# Altium schematic sheet Main.SchDoc
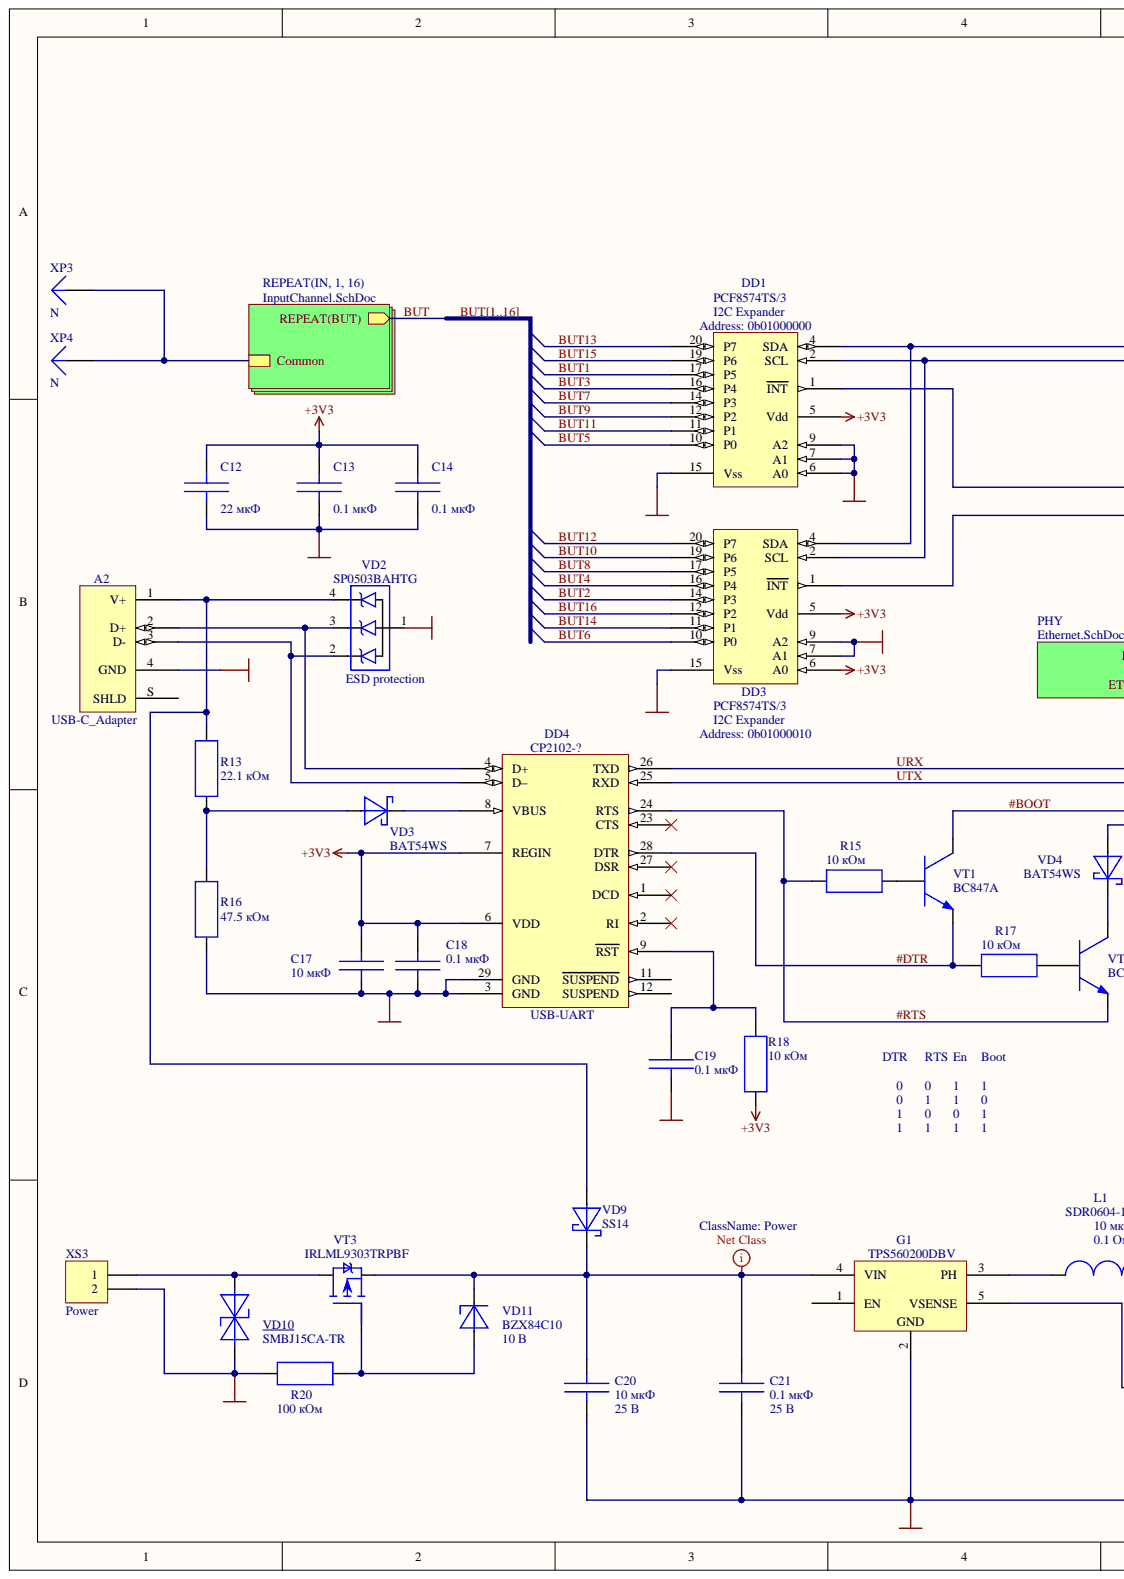
[diagram p0: Main.SchDoc, left]
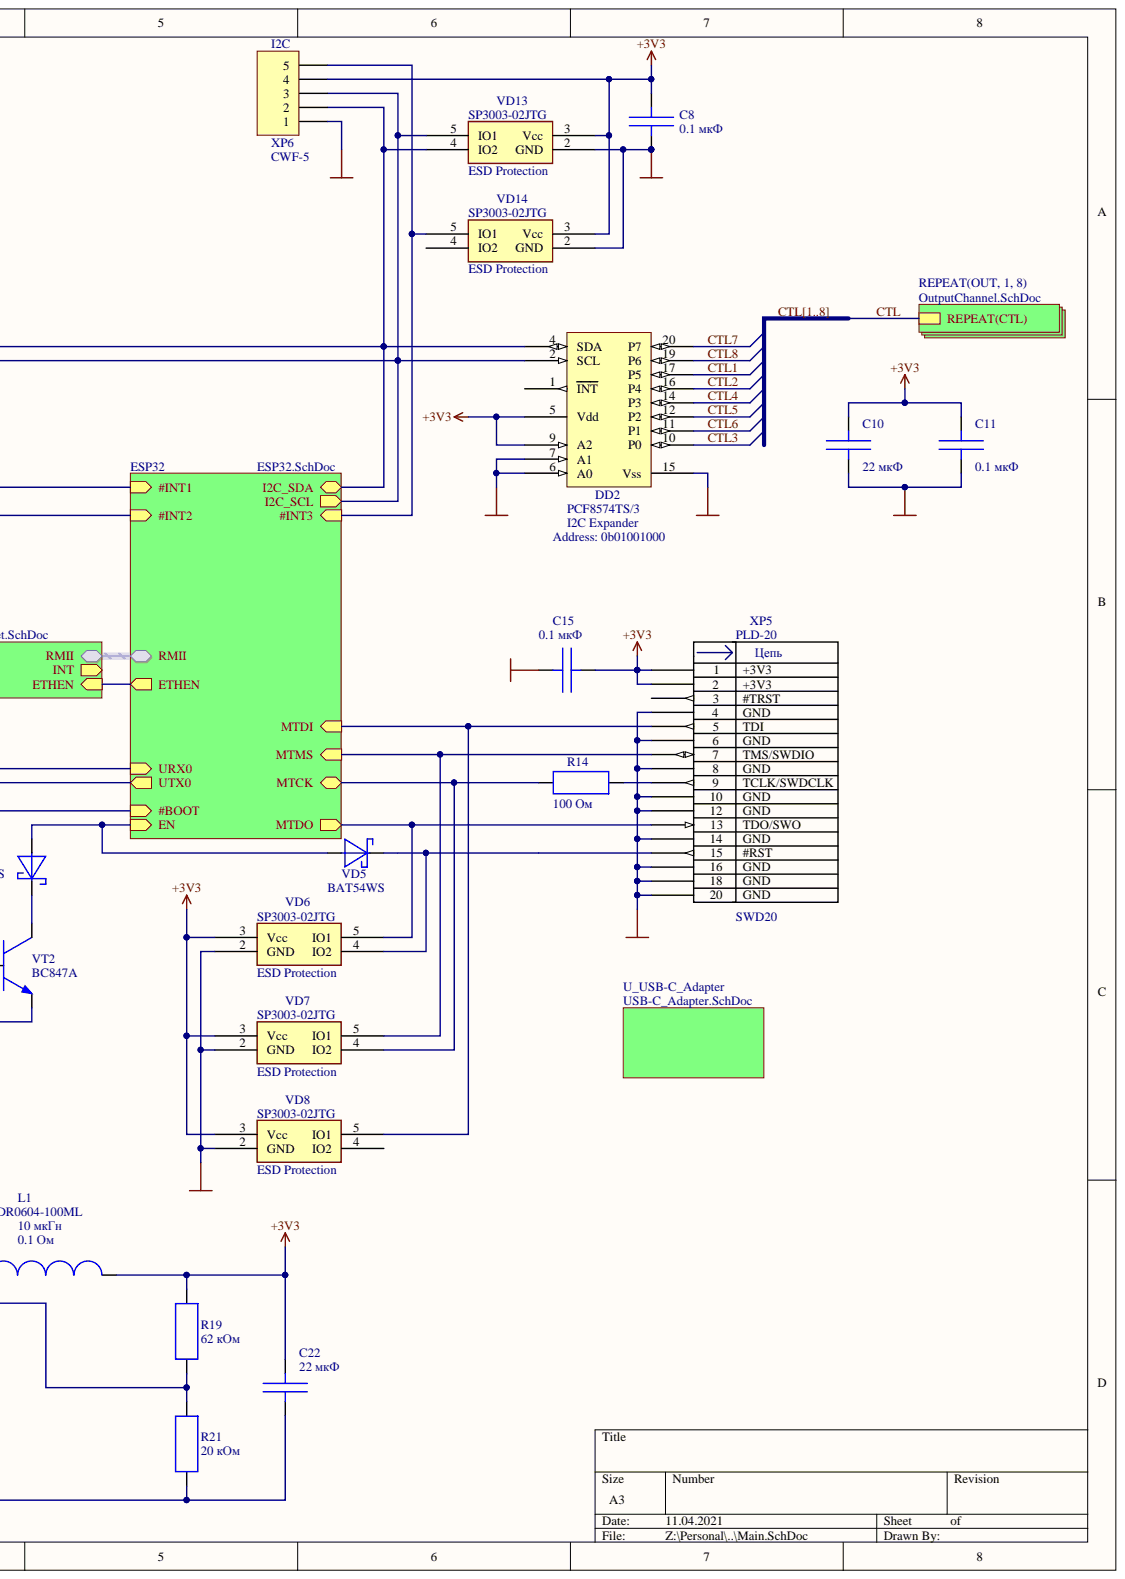
[diagram p1: Main.SchDoc, right]

## Parts
A2  USB-C_Adapter  [Usb-C_Adapter]  (no BOM)
C8  value 0.1 мкФ  [CAP_0603-1608]  (hidden comment: Cap)
C10  value 22 мкФ  [CAP_0805-2012]  (hidden comment: Cap)
C11  value 0.1 мкФ  [CAP_0603-1608]  (hidden comment: Cap)
C12  value 22 мкФ  [CAP_0805-2012]  (hidden comment: Cap)
C13  value 0.1 мкФ  [CAP_0603-1608]  (hidden comment: Cap)
C14  value 0.1 мкФ  [CAP_0603-1608]  (hidden comment: Cap)
C15  value 0.1 мкФ  [CAP_0603-1608]  (hidden comment: Cap)
C17  value 10 мкФ  [CAP_0603-1608]  (hidden comment: Cap)
C18  value 0.1 мкФ  [CAP_0603-1608]  (hidden comment: Cap)
C19  value 0.1 мкФ  [CAP_0603-1608]  (hidden comment: Cap)
C20  value 10 мкФ  Voltage: 25 В  [CAP_1206-3216]  (hidden comment: Cap)
C21  value 0.1 мкФ  Voltage: 25 В  [CAP_0805-2012]  (hidden comment: Cap)
C22  value 22 мкФ  [CAP_1206-3216]  (hidden comment: Cap)
DD1  I2C Expander  Address: 0b01000000  [TSSOP-0.65-20]
DD2  I2C Expander  [TSSOP-0.65-20]
DD3  I2C Expander  [TSSOP-0.65-20]
DD4  USB-UART  Type: CP2102-?  [QFN-0.5-28]
G1  Type: TPS560200DBV  [SOT-23-5]  (hidden comment: DC/DC converter)
L1  value 10 мкГн  Resistance: 0.1 Ом  [SDR0604]  (hidden comment: Inductor)
R13  value 22.1 кОм  [RES_0603-1608]  (hidden comment: Res)
R14  value 100 Ом  [RES_0603-1608]  (hidden comment: Res)
R15  value 10 кОм  [RES_0603-1608]  (hidden comment: Res)
R16  value 47.5 кОм  [RES_0603-1608]  (hidden comment: Res)
R17  value 10 кОм  [RES_0603-1608]  (hidden comment: Res)
R18  value 10 кОм  [RES_0603-1608]  (hidden comment: Res)
R19  value 62 кОм  [RES_0603-1608]  (hidden comment: Res)
R20  value 100 кОм  [RES_0603-1608]  (hidden comment: Res)
R21  value 20 кОм  [RES_0603-1608]  (hidden comment: Res)
VD2  ESD protection  [SOT143_343127P600-8N]
VD3  Type: BAT54WS  [SOD-323]  (hidden comment: Diode_Schottky)
VD4  Type: BAT54WS  [SOD-323]  (hidden comment: Diode_Schottky)
VD5  Type: BAT54WS  [SOD-323]  (hidden comment: Diode_Schottky)
VD6  ESD Protection  Type: SP3003-02JTG  [SC70-5]
VD7  ESD Protection  Type: SP3003-02JTG  [SC70-5]
VD8  ESD Protection  Type: SP3003-02JTG  [SC70-5]
VD9  Type: SS14  [Diode_SMA]  (hidden comment: Diode_Schottky)
VD10  Type: SMBJ15CA-TR  [Diode_SMB_NP]  (hidden comment: Diode_TVS)
VD11  Type: BZX84C10  Voltage: 10 В  [SOT-23-3]  (hidden comment: Diode_Zener)
VD13  ESD Protection  Type: SP3003-02JTG  [SC70-5]
VD14  ESD Protection  Type: SP3003-02JTG  [SC70-5]
VT1  Type: BC847A  [SOT-23-3]  (hidden comment: Transistor_NPN)
VT2  Type: BC847A  [SOT-23-3]  (hidden comment: Transistor_NPN)
VT3  Type: IRLML9303TRPBF  [SOT-23-3]  (hidden comment: Transistor_P-MOSFET_Enh_Bulk_Shot)
XP3  N  [EK508V_Pin]
XP4  N  [EK508V_Pin]
XP5  SWD20  Type: PLD-20  [IDC-20MS]
XP6  I2C  [CWF-5]
XS3  Power  [DS-261]

## Nets
+3V3: C8.2 C10.2 C11.2 C12.2 C13.2 C14.2 C15.1 C17.2 C18.2 C22.2 DD1.5(Vdd) DD2.5(Vdd) DD2.9(A2) DD3.5(Vdd) DD3.6(A0) DD4.6(VDD) DD4.7(REGIN) L1.2 R18.1 R19.1 VD6.3(Vcc) VD7.3(Vcc) VD8.3(Vcc) VD13.3(Vcc) VD14.3(Vcc) XP5.1 XP5.2 XP6.4
GND: A2.4(GND) C8.1 C10.1 C11.1 C12.1 C13.1 C14.1 C15.2 C17.1 C18.1 C19.1 C20.1 C21.1 C22.1 DD1.6(A0) DD1.7(A1) DD1.9(A2) DD1.15(Vss) DD2.6(A0) DD2.7(A1) DD2.15(Vss) DD3.7(A1) DD3.9(A2) DD3.15(Vss) DD4.3(GND) DD4.29(GND) G1.2 R16.1 R20.2 R21.2 VD2.1 VD6.2(GND) VD7.2(GND) VD8.2(GND) VD10.1 VD13.2(GND) VD14.2(GND) XP5.4 XP5.6 XP5.8 XP5.10 XP5.12 XP5.14 XP5.16 XP5.18 XP5.20 XP6.1 XS3.2
#BOOT: VT1.3
#DTR: DD4.28(DTR) R17.1 VT1.2
#RTS: DD4.24(RTS) R15.1 VT2.2
BUT1: DD1.17(P5)  [also labelled BUT]
BUT2: DD3.14(P3)  [also labelled BUT]
BUT3: DD1.16(P4)  [also labelled BUT]
BUT4: DD3.16(P4)  [also labelled BUT]
BUT5: DD1.10(P0)  [also labelled BUT]
BUT6: DD3.10(P0)  [also labelled BUT]
BUT7: DD1.14(P3)  [also labelled BUT]
BUT8: DD3.17(P5)  [also labelled BUT]
BUT9: DD1.12(P2)  [also labelled BUT]
BUT10: DD3.19(P6)  [also labelled BUT]
BUT11: DD1.11(P1)  [also labelled BUT]
BUT12: DD3.20(P7)  [also labelled BUT]
BUT13: DD1.20(P7)  [also labelled BUT]
BUT14: DD3.11(P1)  [also labelled BUT]
BUT15: DD1.19(P6)  [also labelled BUT]
BUT16: DD3.12(P2)  [also labelled BUT]
CTL1: DD2.17(P5)  [also labelled CTL]
CTL2: DD2.16(P4)  [also labelled CTL]
CTL3: DD2.10(P0)  [also labelled CTL]
CTL4: DD2.14(P3)  [also labelled CTL]
CTL5: DD2.12(P2)  [also labelled CTL]
CTL6: DD2.11(P1)  [also labelled CTL]
CTL7: DD2.20(P7)  [also labelled CTL]
CTL8: DD2.19(P6)  [also labelled CTL]
URX: DD4.26(TXD)
UTX: DD4.25(RXD)
NetA2_1: A2.1(V+) R13.2 VD2.4 VD9.1
NetA2_2: A2.2(D+) DD4.4(D+) VD2.3
NetA2_3: A2.3(D-) DD4.5(D–) VD2.2
NetA2_S: A2.S(SHLD)
NetC19_2: C19.2 DD4.9(RST) R18.2
NetC20_2: C20.2 C21.2 G1.4(VIN) VD9.2 VD11.2 VT3.2
NetDD1_1: DD1.1(INT)
NetDD1_2: DD1.2(SCL) DD2.2(SCL) DD3.2(SCL) VD13.5(IO1) XP6.3
NetDD1_4: DD1.4(SDA) DD2.4(SDA) DD3.4(SDA) VD13.4(IO2) XP6.2
NetDD2_1: DD2.1(INT)
NetDD3_1: DD3.1(INT)
NetDD4_1: DD4.1(DCD)
NetDD4_2: DD4.2(RI)
NetDD4_8: DD4.8(VBUS) VD3.2
NetDD4_11: DD4.11(SUSPEND)
NetDD4_12: DD4.12(SUSPEND)
NetDD4_23: DD4.23(CTS)
NetDD4_27: DD4.27(DSR)
NetG1_1: G1.1(EN)
NetG1_3: G1.3(PH) L1.1
NetG1_5: G1.5(VSENSE) R19.2 R21.1
NetR13_1: R13.1 R16.2 VD3.1
NetR14_1: R14.1 XP5.9
NetR14_2: R14.2 VD7.4(IO2)
NetR15_2: R15.2 VT1.1
NetR17_2: R17.2 VT2.1
NetR20_1: R20.1 VD11.1 VT3.1
NetVD4_1: VD4.1 VD5.1
NetVD4_2: VD4.2 VT2.3
NetVD5_2: VD5.2 VD6.4(IO2) XP5.15
NetVD6_5: VD6.5(IO1) XP5.13
NetVD7_5: VD7.5(IO1) XP5.7
NetVD8_4: VD8.4(IO2)
NetVD8_5: VD8.5(IO1) XP5.5
NetVD10_2: VD10.2 VT3.3 XS3.1
NetVD14_4: VD14.4(IO2)
NetVD14_5: VD14.5(IO1) XP6.5
NetXP3_1: XP3.1 XP4.1
NetXP5_3: XP5.3
ETHEN:
INT:
RMII.CLKIN:
RMII.MDC:
RMII.MDIO:
RMII.RXCRS:
RMII.RXD0:
RMII.RXD1:
RMII.TXD0:
RMII.TXD1:
RMII.TXEN:

## Library data of the parts
C8 = Cap: ЧИП конденсатор керамический; BomGroup: Конденсаторы; BomTitle: Конденсатор керамический SMD; Dielectric: X7R; Tolerance: 10%; Type: GRM188R71H104K; Voltage: 50 В; Case: 0603; Component Kind: Standard; Library Name: GOST_Generic.IntLib
C10 C12 = Cap: Конденсатор; BomGroup: Конденсаторы; BomTitle: Конденсатор керамический SMD; Dielectric: X5R; Type: GRM21BR60J226M; Voltage: 6.3 В; Case: 0805; Component Kind: Standard; Library Name: GOST_Generic.IntLib
C11 C13-C15 C18 C19 = Cap: Конденсатор; BomGroup: Конденсаторы; BomTitle: Конденсатор керамический SMD; Dielectric: X7R; Type: GRM188R71H104K; Voltage: 50 В; Case: 0603; Component Kind: Standard; Library Name: GOST_Generic.IntLib
C17 = Cap: ЧИП конденсатор керамический; BomGroup: Конденсаторы; BomTitle: Конденсатор керамический SMD; Dielectric: X5R; Tolerance: 10%; Type: GRM188R60J106ME47D; Voltage: 6.3 В; Case: 0603; Component Kind: Standard; Library Name: GOST_Generic.IntLib
C20 = Cap: ЧИП конденсатор керамический; BomGroup: Конденсаторы; BomTitle: Конденсатор керамический SMD; Dielectric: X5R; Tolerance: 10%; Type: GRM31CR71E106KA12L; Case: 1206; Component Kind: Standard; Library Name: GOST_Generic.IntLib
C21 = Cap: ЧИП конденсатор керамический; BomGroup: Конденсаторы; BomTItle: Конденсатор керамический SMD; Dielectric: X7R; Tolerance: 10%; Type: GRM21BR71E104KA01L; Case: 0805; Component Kind: Standard; Library Name: GOST_Generic.IntLib
C22 = Cap: ЧИП конденсатор керамический; BomGroup: Конденсаторы; BomTitle: Конденсатор керамический SMD; Dielectric: X5R; Tolerance: 20%; Type: GRM31CR61C226ME15L; Voltage: 16 В; Case: 1206; Component Kind: Standard; Library Name: GOST_Generic.IntLib
DD1 = PCF8574TS/3: Remote 8-bit I/O expander for I2C-bus with interrupt; AddressTemplate: 0b0100xxx0; BomGroup: Микросхемы; BomTitle: Микросхема; Component Kind: Standard; Type: PCF8574TS/3; Library Name: Микросхемы интерфейсов.SchLib
DD2 = PCF8574TS/3: Remote 8-bit I/O expander for I2C-bus with interrupt; Address: 0b01001000; AddressTemplate: 0b0100xxx0; BomGroup: Микросхемы; BomTitle: Микросхема; Component Kind: Standard; Type: PCF8574TS/3; Library Name: Микросхемы интерфейсов.SchLib
DD3 = PCF8574TS/3: Remote 8-bit I/O expander for I2C-bus with interrupt; Address: 0b01000010; AddressTemplate: 0b0100xxx0; BomGroup: Микросхемы; BomTitle: Микросхема; Component Kind: Standard; Type: PCF8574TS/3; Library Name: Микросхемы интерфейсов.SchLib
DD4 = CP2102_QFN28: SINGLE-CHIP USB-TO-UART BRIDGE; BomGroup: Микросхемы; BomTitle: Микросхема; Component Kind: Standard; Library Name: Микросхемы интерфейсов.SchLib
G1 = TPS560200: 4.5-V to 17-V Input, 500-mA Synchronous Step-Down Converter With  Advanced Eco-Mode; BomGroup: Микросхемы; BomTitle: Микросхема; Case: SOT23-5; Component Kind: Standard; Library Name: Импульсные источники питания.SchLib
L1 = Inductor: ЧИП катушка индуктивности; BomTitle: Индуктивность SMD; Tolerance: 20%; Type: SDR0604-100ML; BomGroup: Индуктивности; Case: SDR0604; Component Kind: Standard; Library Name: GOST_Generic.IntLib
R13-R18 = Res: Резистор постоянный; BomGroup: Резисторы; BomTitle: Резистор SMD; Power: 0.125 W; Tolerance: 5%; Case: 0603; Component Kind: Standard; Library Name: GOST_Generic.IntLib
R19 = Res: ЧИП резистор постоянный; BomGroup: Резисторы; BomTitle: Резистор SMD; Power: 0.1 W; Tolerance: 1%; Case: 0603; Component Kind: Standard; Type: CRCW060362K0FK; Library Name: GOST_Generic.IntLib
R20 = Res: ЧИП резистор постоянный; BomGroup: Резисторы; BomTitle: Резистор SMD; Power: 0.1 W; Tolerance: 5%; Case: 0603; Component Kind: Standard; Library Name: GOST_Generic.IntLib
R21 = Res: ЧИП резистор постоянный; BomGroup: Резисторы; BomTitle: Резистор SMD; Tolerance: 1%; Case: 0603; Component Kind: Standard; Type: CRCW060320K0FKEA; Library Name: GOST_Generic.IntLib
VD2 = SP0503BAHTG: 3 Channel 30pF 30kV Unidirectional TVS Array; BomGroup: Микросхемы; BomTitle: Микросхема; Component Kind: Standard; Type: SP0503BAHTG; Library Name: Устройства защиты от ESD.SchLib
VD3-VD5 VD9 = Diode_Schottky: Диод Шоттки; BomGroup: Диоды; BomTitle: Диод Шоттки; Component Kind: Standard; Library Name: GOST_Generic.IntLib
  VD3-VD5: Case: SOD-323
  VD9: Case: SMA
VD6-VD8 VD13 VD14 = SP3003-02J: BomGroup: Микросхемы; BomTitle: Микросхема; Case: SC70-5; Component Kind: Standard; Library Name: Устройства защиты от ESD.SchLib
VD10 = Diode_TVS: Диод лавинный двуханодный (TVS, супрессор); BomGroup: Диоды; BomTitle: Супрессор; Case: SMB; Component Kind: Standard; Library Name: GOST_Generic.IntLib
VD11 = Diode_Zener: Диод лавинный выпрямительный (стабилитрон); BomGroup: Диоды; BomTitle: Стабилитрон; Case: SOT-23-3; Component Kind: Standard; Library Name: GOST_Generic.IntLib
VT1 VT2 = Transistor_NPN: Транзистор биполярный NPN; BomGroup: Транзисторы; BomTitle: Транзистор биполярный; Case: SOT23-3; Component Kind: Standard; Library Name: GOST_Generic.IntLib
VT3 = Transistor_P-MOSFET_Enh_Bulk_Shot: P-канальный полевой транзистор с изолированным затвором обогащенного типа с соединением истока и подложки c диодом Шоттки; BomGroup: Транзисторы; BomTitle: Транзистор P-канальный; Case: SOT-23-3; Component Kind: Standard; Library Name: GOST_Generic.IntLib
XP3 XP4 = Plug: Component Kind: Standard; Description: Plug; LatestRevisionDate: 17-Jul-2002; LatestRevisionNote: Re-released for DXP Platform.; Library Name: Miscellaneous Connectors.IntLib; Signal Integrity: Connector; Publisher: Altium Limited
XP5 = SWD20: SWD connector; BomGroup: Соединители; BomTitle: Соединитель штыревой; Component Kind: Standard; Library Name: Управление питанием.SchLib
XP6 = Header 5: Header, 5-Pin; BomGroup: Соединители; BomTitle: Соединитель штыревой; Component Kind: Standard; Library Name: Miscellaneous Connectors.IntLib; Signal Integrity: Connector; Type: CWF-5
XS3 = Header 2: BomGroup: Соединители; BomTitle: Клеммник нажимной; Component Kind: Standard; Library Name: Miscellaneous Connectors.IntLib; Signal Integrity: Connector; Type: DG141V-2.54-02P
A2 = USB-C_Adapter: Library Name: CustomSymbols.SchLib
